# Altium schematic sheet 250808_Flowdeck.SchDoc
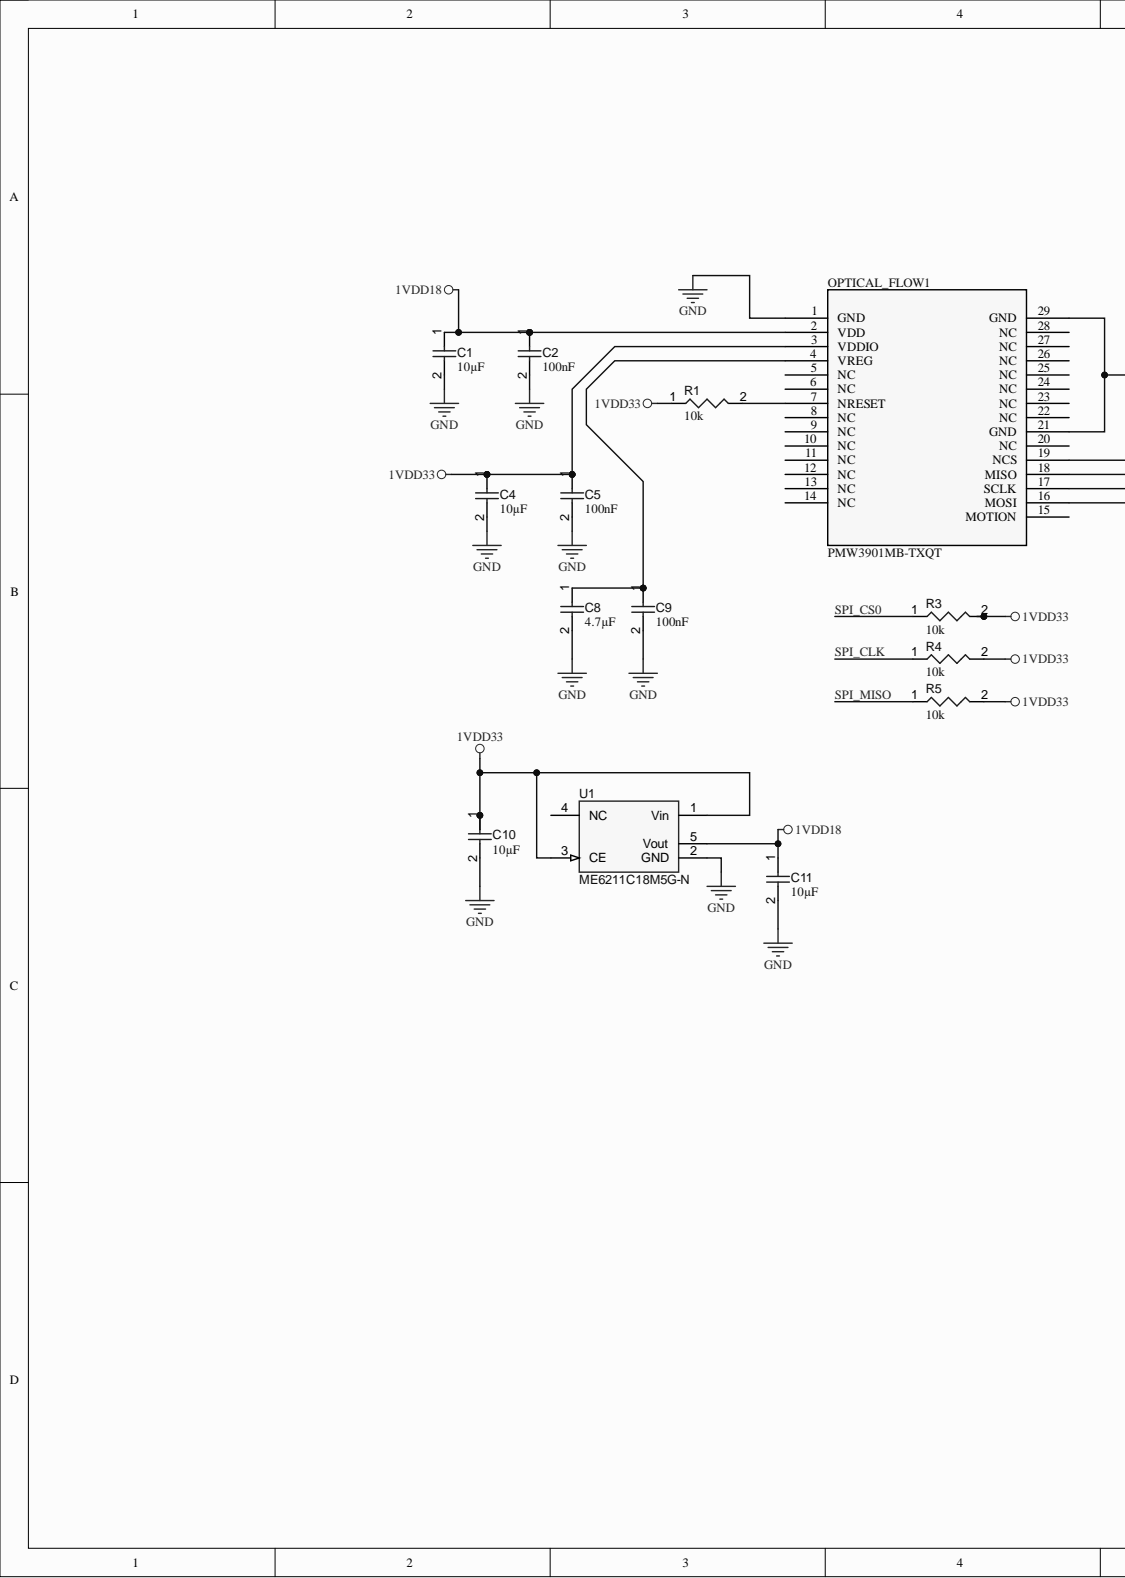
[diagram p0: 250808_Flowdeck.SchDoc, left]
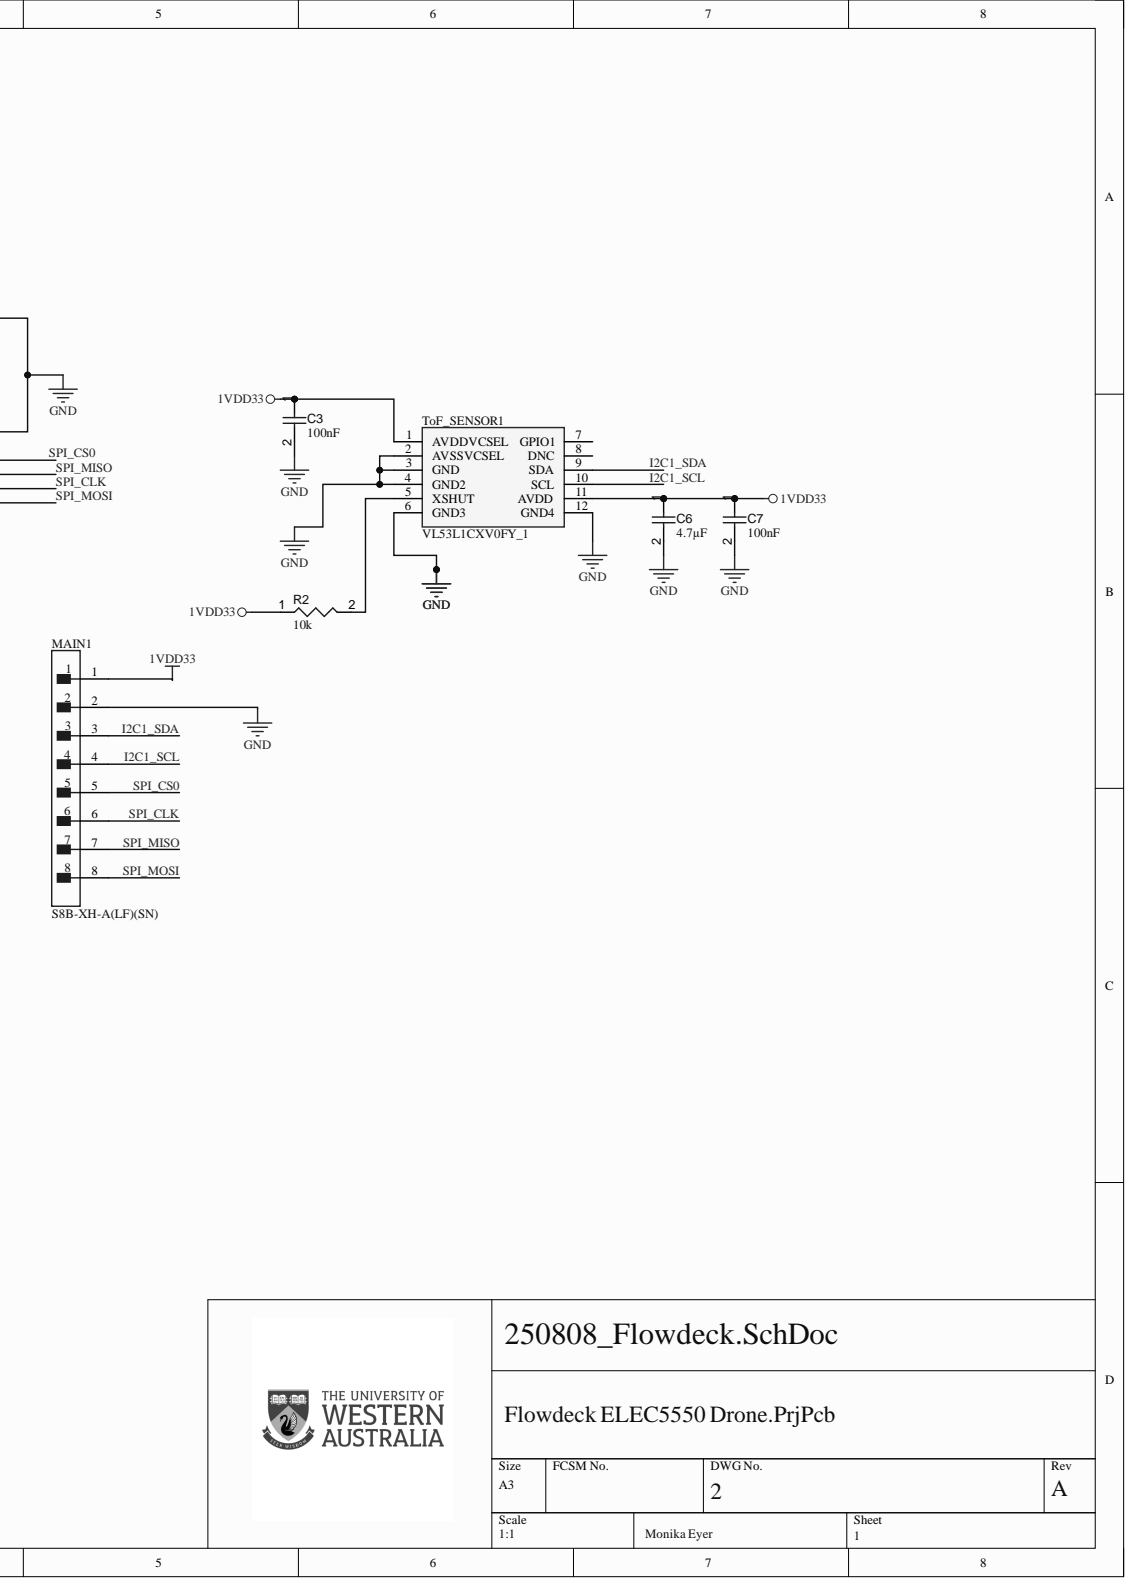
[diagram p1: 250808_Flowdeck.SchDoc, right]

## Parts
C1  value 10µF  [CAPC0603(1608)100_L]  (hidden comment: Capacitor 10µF +/-20% 10V 0603)
C2  value 100nF  [CAPC1608X90X40NL10T20]  (hidden comment: Capacitor 100 nF +/-10% 50 V 0603)
C3  value 100nF  [CAPC1608X90X40NL10T20]  (hidden comment: Capacitor 100 nF +/-10% 50 V 0603)
C4  value 10µF  [CAPC0603(1608)100_L]  (hidden comment: Capacitor 10µF +/-20% 10V 0603)
C5  value 100nF  [CAPC1608X90X40NL10T20]  (hidden comment: Capacitor 100 nF +/-10% 50 V 0603)
C6  value 4.7µF  [CAPC0603(1608)100_L]  (hidden comment: Capacitor 4.7µF +/-20% 10V 0603)
C7  value 100nF  [CAPC1608X90X40NL10T20]  (hidden comment: Capacitor 100 nF +/-10% 50 V 0603)
C8  value 4.7µF  [CAPC0603(1608)100_L]  (hidden comment: Capacitor 4.7µF +/-20% 10V 0603)
C9  value 100nF  [CAPC1608X90X40NL10T20]  (hidden comment: Capacitor 100 nF +/-10% 50 V 0603)
C10  value 10µF  [CAPC0603(1608)100_L]  (hidden comment: Capacitor 10µF +/-20% 10V 0603)
C11  value 10µF  [CAPC0603(1608)100_L]  (hidden comment: Capacitor 10µF +/-20% 10V 0603)
MAIN1  S8B-XH-A(LF)(SN)  [FP-S8B-XH-A_LF_SN-MFG]
OPTICAL_FLOW1  PMW3901MB-TXQT  [QFN_01MB-TXQT_PIX]
R1  value 10k  [RESC1608X55X25ML10T15]  (hidden comment: Resistor 10k +/-1% 0603 100 mW)
R2  value 10k  [RESC1608X55X25ML10T15]  (hidden comment: Resistor 10k +/-1% 0603 100 mW)
R3  value 10k  [RESC1608X55X25ML10T15]  (hidden comment: Resistor 10k +/-1% 0603 100 mW)
R4  value 10k  [RESC1608X55X25ML10T15]  (hidden comment: Resistor 10k +/-1% 0603 100 mW)
R5  value 10k  [RESC1608X55X25ML10T15]  (hidden comment: Resistor 10k +/-1% 0603 100 mW)
ToF_SENSOR1  VL53L1CXV0FY_1  [VL53L1CXV0FY1]
U1  ME6211C18M5G-N  [SOT95P245X145-5N]

## Nets
1VDD18: C1.1 C2.1 C11.1 OPTICAL_FLOW1.2(VDD) U1.5(Vout)
1VDD33: C3.1 C4.1 C5.1 C6.1 C7.1 C10.1 MAIN1.1 OPTICAL_FLOW1.3(VDDIO) R1.1 R2.1 R3.2 R4.2 R5.2 ToF_SENSOR1.1(AVDDVCSEL) ToF_SENSOR1.11(AVDD) U1.1(Vin) U1.3(CE)
GND: C1.2 C2.2 C3.2 C4.2 C5.2 C6.2 C7.2 C8.2 C9.2 C10.2 C11.2 MAIN1.2 OPTICAL_FLOW1.1(GND) OPTICAL_FLOW1.21(GND) OPTICAL_FLOW1.29(GND) ToF_SENSOR1.2(AVSSVCSEL) ToF_SENSOR1.3(GND) ToF_SENSOR1.4(GND2) ToF_SENSOR1.6(GND3) ToF_SENSOR1.12(GND4) U1.2(GND)
I2C1_SCL: MAIN1.4 ToF_SENSOR1.10(SCL)
I2C1_SDA: MAIN1.3 ToF_SENSOR1.9(SDA)
SPI_CLK: MAIN1.6 OPTICAL_FLOW1.17(SCLK) R4.1
SPI_CS0: MAIN1.5 OPTICAL_FLOW1.19(NCS) R3.1
SPI_MISO: MAIN1.7 OPTICAL_FLOW1.18(MISO) R5.1
SPI_MOSI: MAIN1.8 OPTICAL_FLOW1.16(MOSI)
NetC8_1: C8.1 C9.1 OPTICAL_FLOW1.4(VREG)
NetOPTICAL_FLOW1_7: OPTICAL_FLOW1.7(NRESET) R1.2
NetR2_2: R2.2 ToF_SENSOR1.5(XSHUT)

Not connected: OPTICAL_FLOW1 5(NC) 6(NC) 8(NC) 9(NC) 10(NC) 11(NC) 12(NC) 13(NC) 14(NC) 15(MOTION) 20(NC) 22(NC) 23(NC) 24(NC) 25(NC) 26(NC) 27(NC) 28(NC); ToF_SENSOR1 7(GPIO1) 8(DNC); U1 4(NC)

## Library data of the parts
C1 C4 C10 C11 = CMP-001-00075-4: Chip Capacitor, 10µF +/-20%, 10V, 0603, Thickness 1 mm; Tolerance: 10%; Case/Package: 0603; Component Kind: Standard; Max Operating Temperature: 85°C; Min Operating Temperature: -55°C; Mounting Technology: SMT; Pins: 2; Voltage Rating: 10V; Library Name: Ray Prasetya
C2 C3 C5 C7 C9 = CMP-001-00017-6: Chip Capacitor, 100 nF, +/- 10%, 50 V, 0603 (1608 Metric); Capacitor Type: Ceramic; Voltage Rating: 50V; Case/Package: 0603; Component Kind: Standard; Max Operating Temperature: 125°C; Min Operating Temperature: -55°C; Mounting Technology: SM; Pins: 2; RoHS Compliant: True; Tolerance: 10%; Library Name: Ray Prasetya
C6 C8 = CMP-001-00076-4: Chip Capacitor, 4.7µF +/-20%, 10V, 0603, Thickness 1 mm; Tolerance: 10%; Case/Package: 0603; Component Kind: Standard; Max Operating Temperature: 85°C; Min Operating Temperature: -55°C; Mounting Technology: SMT; Pins: 2; Voltage Rating: 10V; Library Name: Ray Prasetya
MAIN1 = CMP-002-00074-1: Conn Shrouded Header (4 Sides) HDR 8 POS 2.5mm Solder RA Thru-Hole Box; Component Kind: Standard; Library Name: Ray Prasetya
OPTICAL_FLOW1 = PMW3901MB-TXQT: No Description Available; Manufacturer_Name: PixArt; Component Kind: Standard; Library Name: PMW3901MB-TXQT.IntLib; Manufacturer_Part_Number: PMW3901MB-TXQT; RefDes: RefDes; Type: Type; Copyright: Copyright (C) 2025 Ultra Librarian. All rights reserved.
R1-R5 = CMP-009-00017-5: Chip Resistor, 10 KOhm, +/- 1%, 01 W, -55 to 155 degC, 0603 (1608 Metric); Power: 100mW; Voltage Rating: 50V; Case/Package: 0603; Component Kind: Standard; Max Operating Temperature: 155°C; Min Operating Temperature: -55°C; Mounting Technology: SM; Pins: 2; RoHS Compliant: True; Tolerance: 1%; Library Name: Ray Prasetya
ToF_SENSOR1 = VL53L1CXV0FY_1: Integrated Circuit; Manufacturer_Name: STMicroelectronics; Manufacturer_Part_Number: VL53L1CXV0FY/1; Arrow Part Number: VL53L1CXV0FY/1; Arrow Price/Stock: https://www.arrow.com/en/products/vl53l1cxv0fy1/stmicroelectronics?utm_currency=USD&region=europe; Component Kind: Standard; Datasheet Link: https://componentsearchengine.com/Datasheets/1/VL53L1CXV0FY_1.pdf; Height: 1mm; Mouser Part Number: 511-VL53L1CXV0FY/1; Mouser Price/Stock: https://www.mouser.co.uk/ProductDetail/STMicroelectronics/VL53L1CXV0FY-1?qs=W0yvOO0ixfFQE5v5L6h6PA%3D%3D
U1 = CMP-019-00051-1: VIN=6.5V Vo=1.8V Io=600mA; Component Kind: Standard; Library Name: Ray Prasetya
